# Altium schematic sheet HBridge2A.SchDoc(HBridge2A)
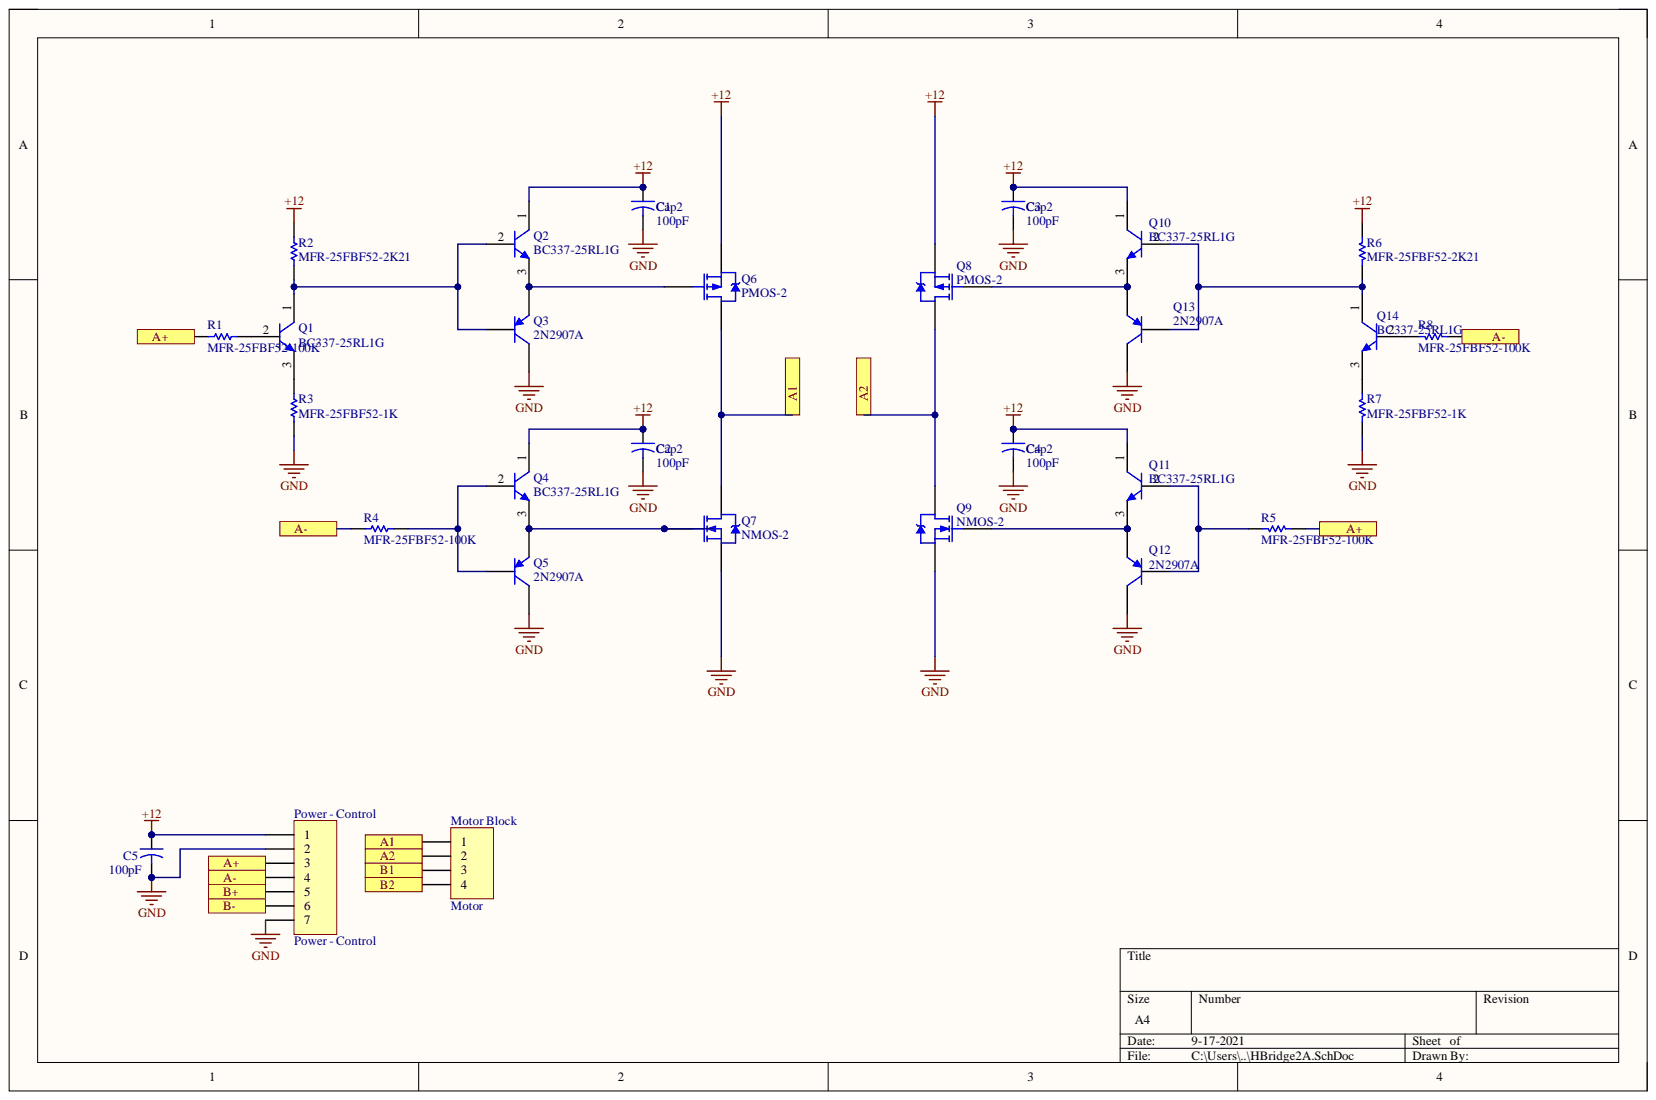
[diagram p0: HBridge2A.SchDoc]

## Parts
C1  Cap2  value 100pF  [AXIAL-0.3]
C2  Cap2  value 100pF  [AXIAL-0.3]
C3  Cap2  value 100pF  [AXIAL-0.3]
C4  Cap2  value 100pF  [AXIAL-0.3]
C5  value 100pF  [220uF(1) - duplicate]  (hidden comment: 220 uF)
Motor_Block  Motor  [MotorBlockTerminal]
Power_-_Control  Power - Control  [HDR1X7]
Q1  BC337-25RL1G  [ONSC-TO-92-3-29-11_AM_BL]
Q2  BC337-25RL1G  [ONSC-TO-92-3-29-11_AM_BL]
Q3  2N2907A  [TO-92A]
Q4  BC337-25RL1G  [ONSC-TO-92-3-29-11_AM_BL]
Q5  2N2907A  [TO-92A]
Q6  PMOS-2  [TO-220AB]
Q7  NMOS-2  [TO-220AB]
Q8  PMOS-2  [TO-220AB]
Q9  NMOS-2  [TO-220AB]
Q10  BC337-25RL1G  [ONSC-TO-92-3-29-11_AM_BL]
Q11  BC337-25RL1G  [ONSC-TO-92-3-29-11_AM_BL]
Q12  2N2907A  [TO-92A]
Q13  2N2907A  [TO-92A]
Q14  BC337-25RL1G  [ONSC-TO-92-3-29-11_AM_BL]
R1  MFR-25FBF52-100K  [RESA60-630X240]
R2  MFR-25FBF52-2K21  [RESA60-630X240]
R3  MFR-25FBF52-1K  [RESA60-630X240]
R4  MFR-25FBF52-100K  [RESA60-630X240]
R5  MFR-25FBF52-100K  [RESA60-630X240]
R6  MFR-25FBF52-2K21  [RESA60-630X240]
R7  MFR-25FBF52-1K  [RESA60-630X240]
R8  MFR-25FBF52-100K  [RESA60-630X240]

## Ports
A1, A2, A+, A-, B1, B2, B+, B-

## Nets
+12: C1.1 C2.1 C3.1 C4.1 C5.1 Power_-_Control.1 Q2.1 Q4.1 Q6.3 Q8.3 Q10.1 Q11.1 R2.2 R6.2
GND: C1.2 C2.2 C3.2 C4.2 C5.2 Power_-_Control.2 Power_-_Control.7 Q3.3 Q5.3 Q7.3 Q9.3 Q12.3 Q13.3 R3.1 R7.1
A1: Motor_Block.1 Q6.2 Q7.2 ; port A1
A2: Motor_Block.2 Q8.2 Q9.2 ; port A2
B1: Motor_Block.3 ; port B1
B2: Motor_Block.4 ; port B2
NetPower - Control_3: Power_-_Control.3 R1.1 R5.1
NetPower - Control_4: Power_-_Control.4 R4.1 R8.1
NetPower - Control_5: Power_-_Control.5
NetPower - Control_6: Power_-_Control.6
NetQ1_1: Q1.1 Q2.2 Q3.2 R2.1
NetQ1_2: Q1.2 R1.2
NetQ1_3: Q1.3 R3.2
NetQ2_3: Q2.3 Q3.1 Q6.1
NetQ4_2: Q4.2 Q5.2 R4.2
NetQ4_3: Q4.3 Q5.1 Q7.1
NetQ8_1: Q8.1 Q10.3 Q13.1
NetQ9_1: Q9.1 Q11.3 Q12.1
NetQ10_2: Q10.2 Q13.2 Q14.1 R6.1
NetQ11_2: Q11.2 Q12.2 R5.2
NetQ14_2: Q14.2 R8.2
NetQ14_3: Q14.3 R7.2

## Library data of the parts
C1-C5 = Cap2: Capacitor; Component Kind: Standard; Library Name: Miscellaneous Devices.IntLib; PackageDescription: Capacitor; 2 Leads; PackageReference: CAPR5-4X5; Published: 23-Sep-2002; Signal Integrity: Cap; Simulation: CAP; Publisher: Altium Limited
Motor_Block = Header 4: Header, 4-Pin; Component Kind: Standard; LatestRevisionDate: 17-Jul-2002; LatestRevisionNote: Re-released for DXP Platform.; Library Name: Miscellaneous Connectors.IntLib; Signal Integrity: Connector; Publisher: Altium Limited
Power_-_Control = Header 7: Header, 7-Pin; Component Kind: Standard; LatestRevisionDate: 17-Jul-2002; LatestRevisionNote: Re-released for DXP Platform.; Library Name: Miscellaneous Connectors.IntLib; Signal Integrity: Connector; Publisher: Altium Limited
Q1 Q2 Q4 Q10 Q11 Q14 = CMP-1048-01576-1: Amplifier Transistor, NPN Silicon, 3-Pin TO-92, Pb-Free, Tape and Reel; Manufacturer: On Semiconductor; IC Continuous (A): 0.8; Component Kind: Standard; ComponentLink1Description: Manufacturer URL; ComponentLink1URL: http://www.onsemi.com/; ComponentLink2Description: Datasheet; ComponentLink2URL: http://www.onsemi.com/pub_link/Collateral/BC337-D.PDF; ComponentLink3Description: Package Specification; ComponentLink3URL: http://www.onsemi.com/pub_link/Collateral/MAC97-D.PDF; DatasheetVersion: Rev. 8; Library Name: Altium Content Vault; Mounting Technology: Through Hole; PackageDescription: 3-Pin Transistor Outline, Body 4.825 x 3.685 mm, Pitch 1.27 mm; PackageReference: TO-92-3-29-11_AM_BL; PackageVersion: Rev. AM; Packing: Tape and Reel; PartNumber: BC337-25RL1G; Polarity: NPN; RoHS: TRUE
Q3 Q5 Q12 Q13 = 2N3906: PNP General Purpose Amplifier; Component Kind: Standard; ComponentLink1Description: Manufacturer Link; ComponentLink1URL: http://www.fairchildsemi.com/; ComponentLink2Description: Datasheet; ComponentLink2URL: http://www.fairchildsemi.com/ds/2N%2F2N3906.pdf; DatasheetVersion: 1997; LatestRevisionDate: 15-Jan-2003; LatestRevisionNote: PCB Footprint 'BCY-W3/D4.7' replaced; Library Name: Miscellaneous Devices.IntLib; PackageDescription: TO, Flat Index; 3 In-Line, Axial Leads; Body Dia. 4.5mm; Leads 0.51 x 0.48 mm (max); PackageReference: TO-92A; PackageVersion: Sep-1998; Published: 8-Jun-2000; Signal Integrity: 2N3906; Simulation: 2N3906; Publisher: Altium Limited
Q6 Q8 = PMOS-2: P-Channel Power MOSFET; Note: Pin 4 not drawn and electrically connected to Pin 2.; Component Kind: Standard; ComponentLink1Description: Manufacturer Link; ComponentLink1URL: http://www.irf.com/; DatasheetVersion: PD-9.390D; LatestRevisionDate: 17-Jul-2002; LatestRevisionNote: Re-released for DXP Platform.; Library Name: Miscellaneous Devices.IntLib; PackageDescription: TO, Thru-Hole, Vertical, Heatsink Mounted; 3 In-Line Leads; Pitch 2.54 mm; PackageReference: TO-220AB; PackageVersion: Feb-1997; Published: 8-Jun-2000; Simulation: IRF9510; Publisher: Altium Limited
Q7 Q9 = NMOS-2: N-Channel Power MOSFET; Note: Pin 2 is electrically connected to pin 4.; Component Kind: Standard; ComponentLink1Description: Manufacturer Link; ComponentLink1URL: http://www.irf.com/; DatasheetVersion: PD-9.814; LatestRevisionDate: 17-Jul-2002; LatestRevisionNote: Re-released for DXP Platform.; Library Name: Miscellaneous Devices.IntLib; PackageDescription: TO, Thru-Hole, Vertical, Heatsink Mounted; 3 In-Line Leads; Pitch 2.54 mm; PackageReference: TO-220AB; PackageVersion: Feb-1997; Published: 8-Jun-2000; Simulation: IRF1010; Publisher: Altium Limited
R1 R4 R5 R8 = CMP-1659-00073-1: Axial Resistor, 100 KOhm, +/- 1%, 0.25 W, -55 to 155 degC, 2-Pin THD, RoHS, Bulk; Power Rating: 250 mW; Resistance: 475 Ohm; Voltage Rating: 250 V; Case\Package: Axial; Component Kind: Standard; ComponentLink1Description: Manufacturer URL; ComponentLink1URL: http://www.yageo.com/; ComponentLink2Description: Datasheet; ComponentLink2URL: http://www.yageo.com/documents/recent/Yageo%20LR_MFR_2013.pdf; DatasheetVersion: Apr-13; Length: 0.248 inch; Library Name: Altium Content Vault; Max Operating Temperature: 155 degC; Min Operating Temperature: -55 degC; Mount: Through Hole; Package Quantity: 200; PackageDescription: 2-Pin Axial Lead, Dia 2.4 mm, Body Length 6.3 mm; PackageReference: MFR-25; Packaging: Bulk; RoHSCompliant: true; Tolerance: 1%
R2 R6 = CMP-1659-00065-1: Axial Resistor, 2.21 KOhm, +/- 1%, 0.25 W, -55 to 155 degC, 2-Pin THD, RoHS, Bulk; Case\Package: 0402; Current Rating: 300 mA; Resistance: 0.15 Ohm; Shielding: Unshielded; Case Code (Imperial): 0402; Case Code (Metric): 1005; Component Kind: Standard; ComponentLink1Description: Manufacturer URL; ComponentLink1URL: http://www.yageo.com/; ComponentLink2Description: Datasheet; ComponentLink2URL: http://www.yageo.com/documents/recent/Yageo%20LR_MFR_2013.pdf; DatasheetVersion: Apr-13; Height: 0.02 inch; Length: 0.04 inch; Library Name: Altium Content Vault; Max Operating Temperature: 125 degC; Min Operating Temperature: -55 degC; Mount: Surface Mount; Package Quantity: 10000; PackageDescription: 2-Pin Axial Lead, Dia 2.4 mm, Body Length 6.3 mm; PackageReference: MFR-25; Packaging: Tape and Reel; Pins: 2; Radiation Hardening: No; REACH SVHC: No SVHC; RoHSCompliant: true; Width: 0.02 inch
R3 R7 = CMP-1659-00075-1: Axial Resistor, 1 KOhm, +/- 1%, 250 mW, -55 to 155 degC, 2-Pin THD, RoHS, Bulk; Power Rating: 250 mW; Resistance: 10 kohm; Voltage Rating: 250 V; Case\Package: Axial; Component Kind: Standard; ComponentLink1Description: Manufacturer URL; ComponentLink1URL: http://www.yageo.com/; ComponentLink2Description: Datasheet; ComponentLink2URL: http://www.yageo.com/documents/recent/Yageo%20LR_MFR_2013.pdf; DatasheetVersion: Apr-13; Length: 0.248 inch; Library Name: Altium Content Vault; Max Operating Temperature: 155 degC; Min Operating Temperature: -55 degC; Package Quantity: 200; PackageDescription: 2-Pin Axial Lead, Dia 2.4 mm, Body Length 6.3 mm; PackageReference: MFR-25; Packaging: Bulk; RoHSCompliant: true; Tolerance: 1%
